# Altium schematic sheet 03_VGA_RGB888.SchDoc
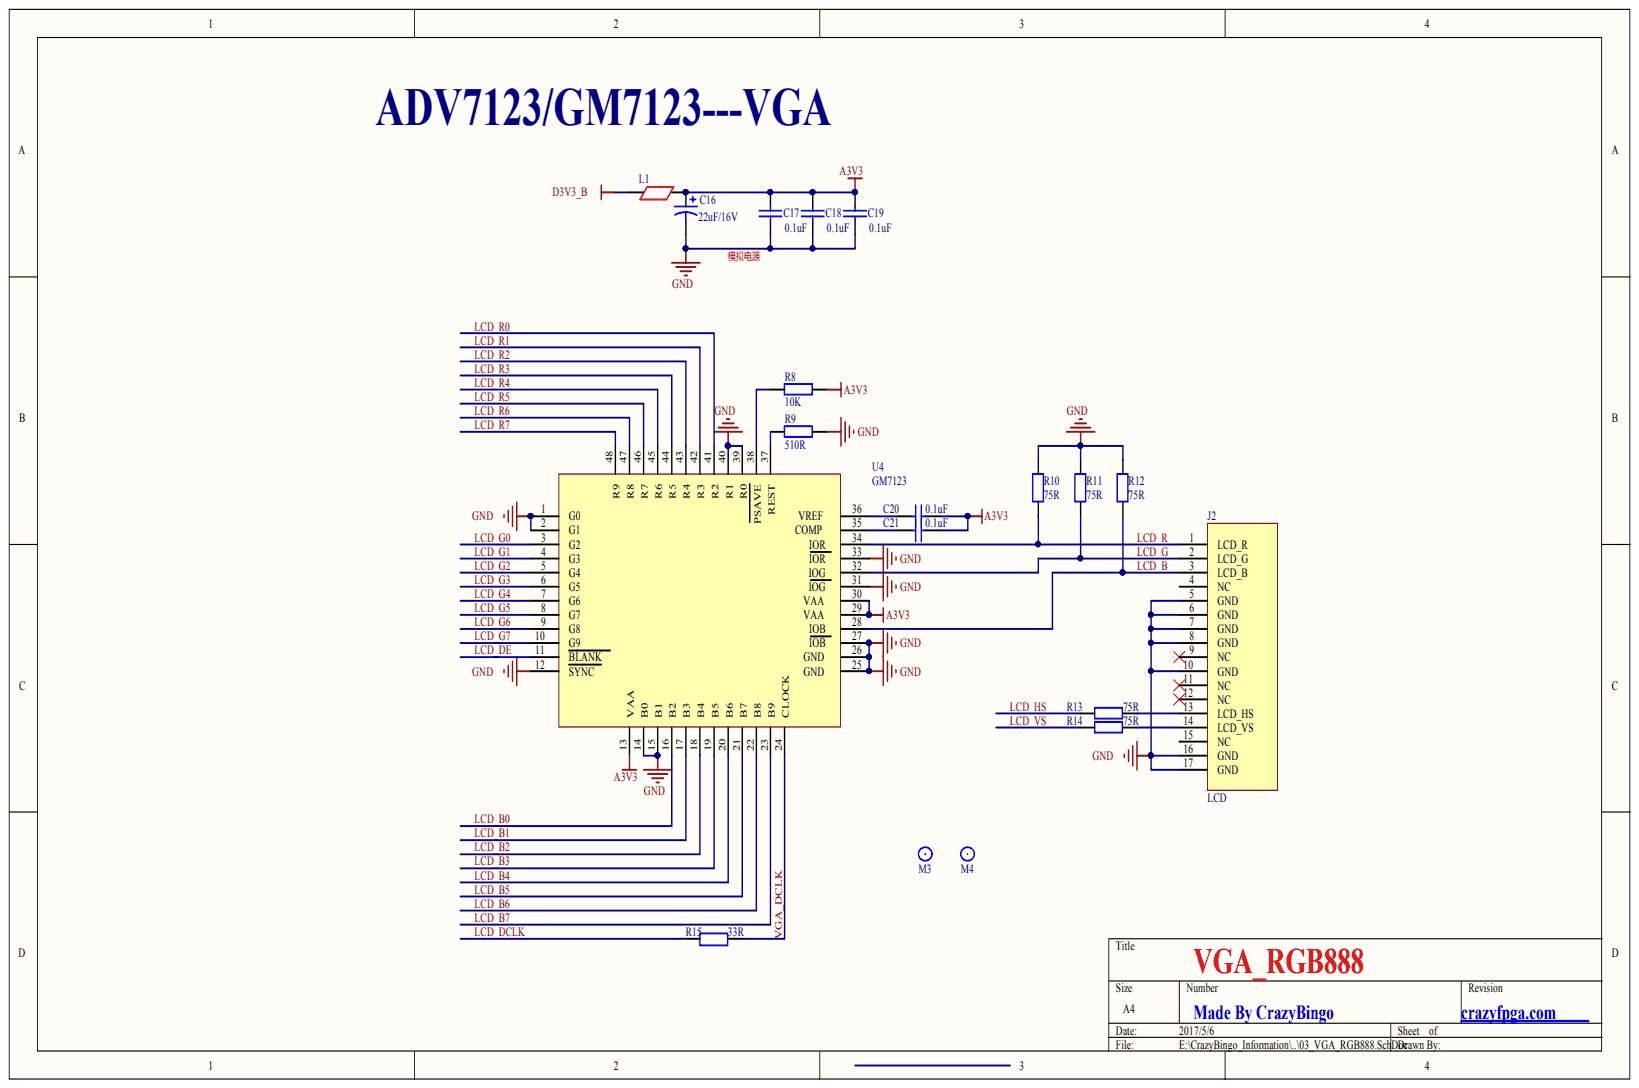
[diagram p0: 03_VGA_RGB888.SchDoc]

## Parts
C16  22uF/16V  [R0805]
C17  0.1uF  [R0603]
C18  0.1uF  [R0603]
C19  0.1uF  [R0603]
C20  0.1uF  [R0603]
C21  0.1uF  [R0603]
J2  LCD  [VGA3]
L1  BLM18PG471SN1D  [r0603]  (value not drawn)
M3  Pin_Mark  [Pin_Mark_One]  (value not drawn)
M4  Pin_Mark  [Pin_Mark_One]  (value not drawn)
R8  10K  [R0603]
R9  510R  [R0603]
R10  75R  [R0603]
R11  75R  [R0603]
R12  75R  [R0603]
R13  75R  [R0603]
R14  75R  [R0603]
R15  33R  [R0603]
U4  GM7123  [tqfp48]

## Nets
A3V3: C16.1 C17.2 C18.2 C19.2 C20.2 C21.2 L1.1 R8.2 U4.13(VAA) U4.29(VAA) U4.30(VAA)
D3V3_B: L1.2
GND: C16.2 C17.1 C18.1 C19.1 J2.5(GND) J2.6(GND) J2.7(GND) J2.8(GND) J2.10(GND) J2.16(GND) J2.17(GND) R9.2 R10.2 R11.2 R12.2 U4.1(G0) U4.2(G1) U4.12(SYNC) U4.14(B0) U4.15(B1) U4.25(GND) U4.26(GND) U4.27(IOB) U4.31(IOG) U4.33(IOR) U4.39(R0) U4.40(R1)
LCD_B: J2.3(LCD_B) R12.1 U4.28(IOB)
LCD_B0: U4.16(B2)
LCD_B1: U4.17(B3)
LCD_B2: U4.18(B4)
LCD_B3: U4.19(B5)
LCD_B4: U4.20(B6)
LCD_B5: U4.21(B7)
LCD_B6: U4.22(B8)
LCD_B7: U4.23(B9)
LCD_DCLK: R15.1
LCD_DE: U4.11(BLANK)
LCD_G: J2.2(LCD_G) R11.1 U4.32(IOG)
LCD_G0: U4.3(G2)
LCD_G1: U4.4(G3)
LCD_G2: U4.5(G4)
LCD_G3: U4.6(G5)
LCD_G4: U4.7(G6)
LCD_G5: U4.8(G7)
LCD_G6: U4.9(G8)
LCD_G7: U4.10(G9)
LCD_HS: R13.1
LCD_R: J2.1(LCD_R) R10.1 U4.34(IOR)
LCD_R0: U4.41(R2)
LCD_R1: U4.42(R3)
LCD_R2: U4.43(R4)
LCD_R3: U4.44(R5)
LCD_R4: U4.45(R6)
LCD_R5: U4.46(R7)
LCD_R6: U4.47(R8)
LCD_R7: U4.48(R9)
LCD_VS: R14.1
VGA_DCLK: R15.2 U4.24(CLOCK)
NetC20_1: C20.1 U4.36(VREF)
NetC21_1: C21.1 U4.35(COMP)
NetJ2_13: J2.13(LCD_HS) R13.2
NetJ2_14: J2.14(LCD_VS) R14.2
NetR8_1: R8.1 U4.38(PSAVE)
NetR9_1: R9.1 U4.37(REST)
N00224:

Not connected: J2 4(NC) 9(NC) 11(NC) 12(NC) 15(NC)

## Library data of the parts
C16 = Cap Pol1: Polarized Capacitor (Radial); Value: 10uF; Component Kind: Standard; LatestRevisionDate: 17-Jul-2002; LatestRevisionNote: Re-released for DXP Platform.; Library Name: Miscellaneous Devices.IntLib; PackageReference: RB7.6-15; Published: 8-Jun-2000; Signal Integrity: Cap Pol; Simulation: CAP; Publisher: Altium Limited
C17-C21 = Cap: Capacitor; Value: 104; Component Kind: Standard; LatestRevisionDate: 17-Jul-2002; LatestRevisionNote: Re-released for DXP Platform.; Library Name: Crazy_PCB.SchLib; PackageReference: RAD-0.3; Published: 8-Jun-2000; Publisher: Altium Limited
J2 = VGA: Component Kind: Standard; Library Name: Crazy_PCB.SchLib
L1 = Bead: Component Kind: Standard; Library Name: Crazy_PCB.SchLib
R8-R14 = RES: Resistor; Value: 1K; Component Kind: Standard; Library Name: Crazy_PCB.SchLib; Published: 8-Jun-2000; Revision: July-2002: Re-released for DXP Platform.; Publisher: Altium Limited
R15 = RES: Component Kind: Standard; Library Name: Crazy_PCB.SchLib
U4 = ADV7123: Component Kind: Standard; Library Name: Crazy_PCB.SchLib
M3 M4 = Pin_Mark: Component Kind: Standard; Library Name: Crazy_PCB.SchLib
